# Altium schematic sheet Sch.SchDoc
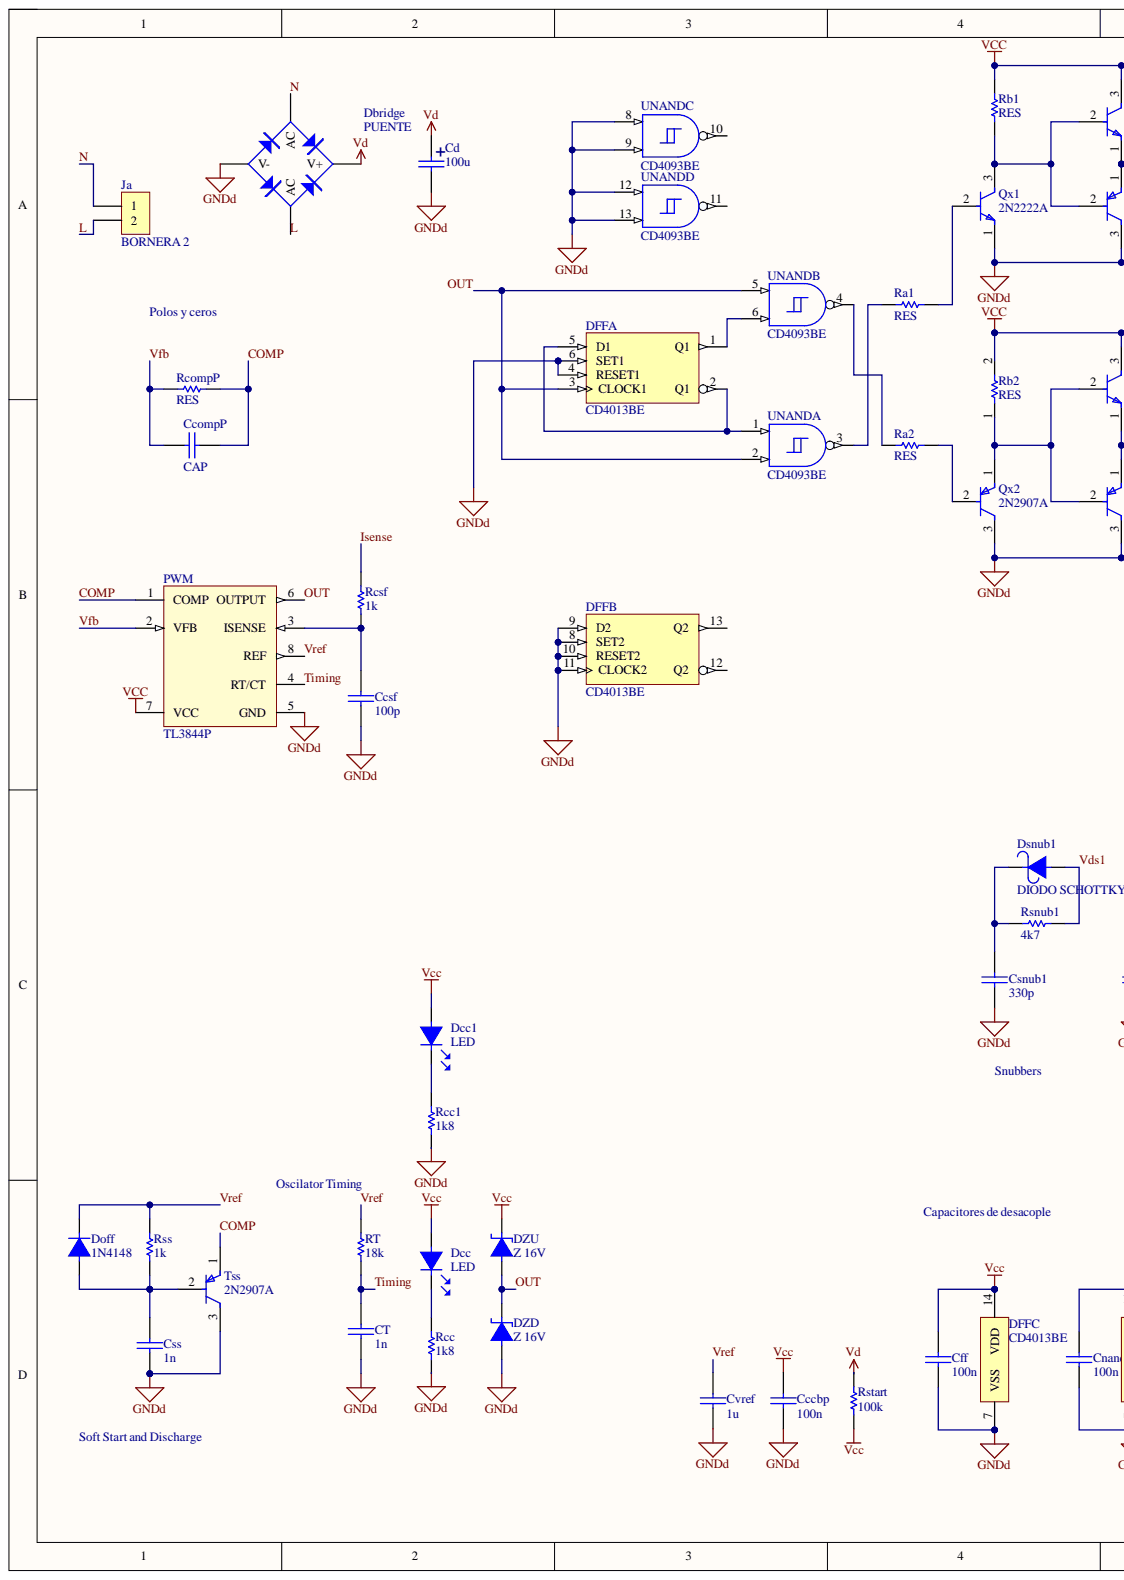
[diagram p0: Sch.SchDoc, left]
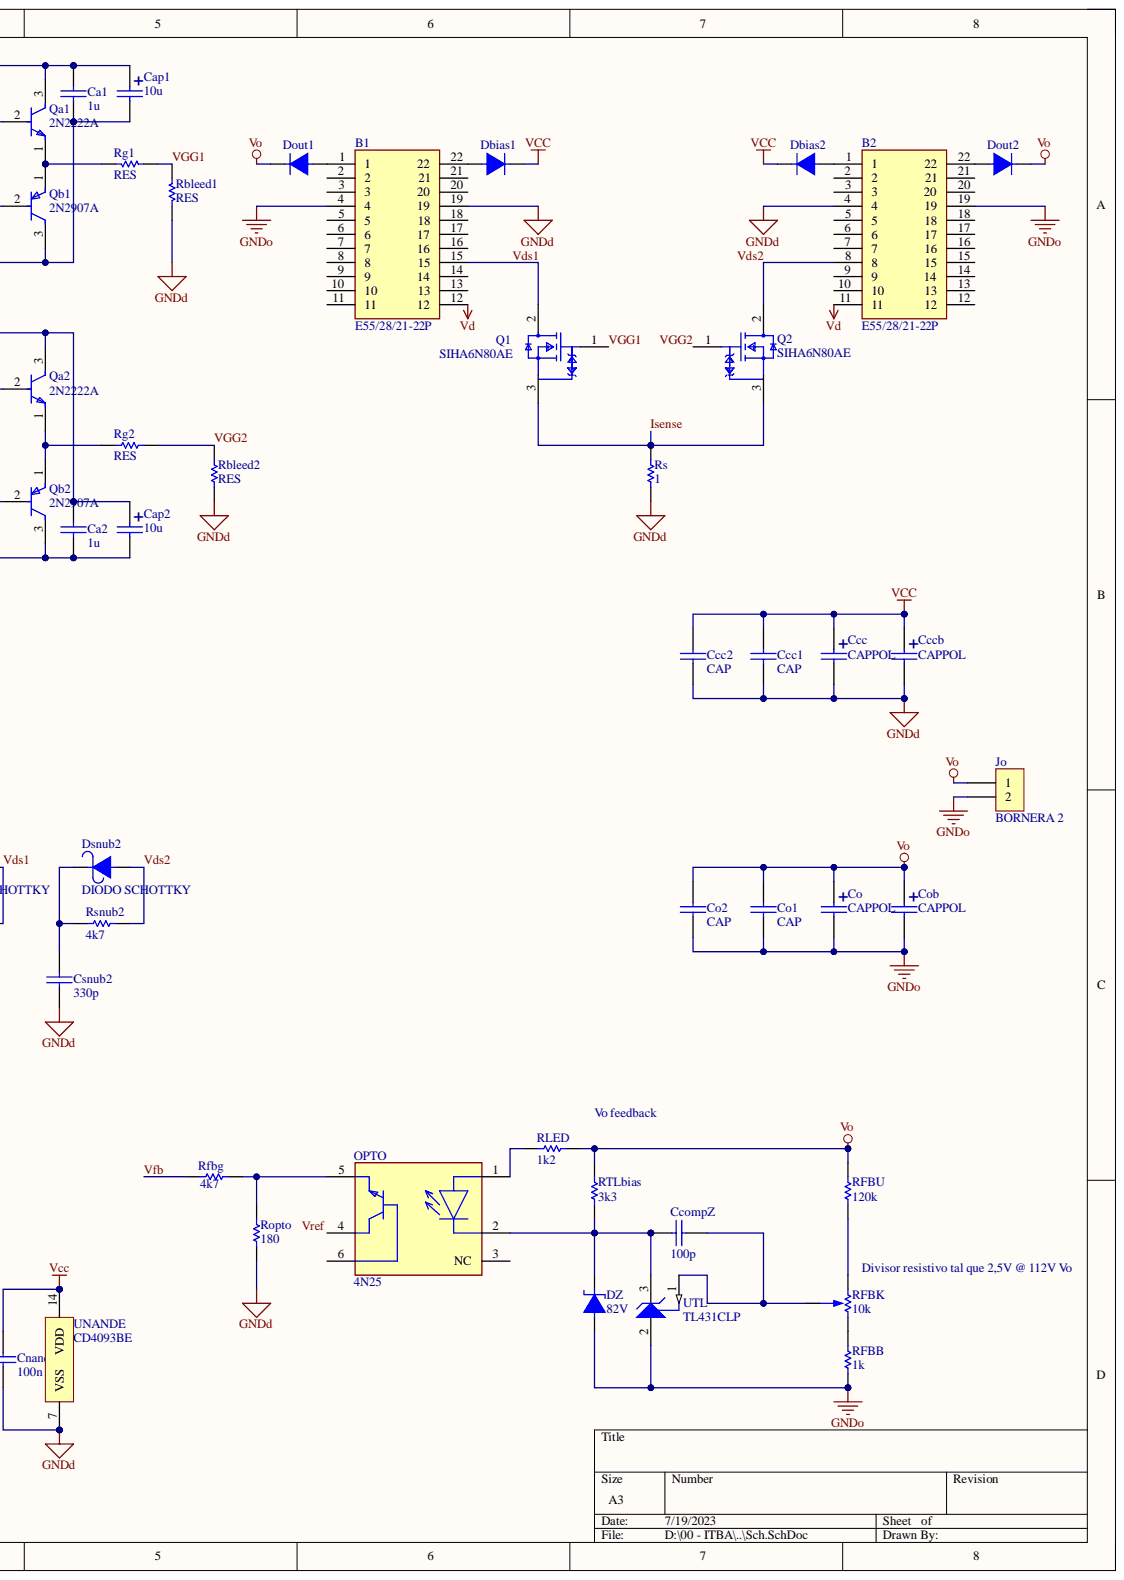
[diagram p1: Sch.SchDoc, right]

## Parts
B1  E55/28/21-22P  [DIPS4000W100P500L5500H4050Q22N]
B2  E55/28/21-22P  [DIPS4000W100P500L5500H4050Q22N]
Ca1  1u  [CAP100]
Ca2  1u  [CAP100]
Cap1  10u  [CAP100RP2]
Cap2  10u  [CAP100RP2]
Ccc  CAPPOL  [CAP200RP]
Ccc1  CAP  [CAP200]
Ccc2  CAP  [CAP200]
Cccb  CAPPOL  [CAP200RP]
Cccbp  100n  [CAP200]
CcompP  CAP  [CAP200]
CcompZ  100p  [CAP200]
Ccsf  100p  [CAP200]
Cd  100u  [CAP200RP]
Cff  100n  [CAP200]
Cnand  100n  [CAP200]
Co  CAPPOL  [CAP200RP]
Co1  CAP  [CAP200]
Co2  CAP  [CAP200]
Cob  CAPPOL  [CAP200RP]
Csnub1  330p  [CAP200]
Csnub2  330p  [CAP200]
Css  1n  [CAP200]
CT  1n  [CAP100]
Cvref  1u  [CAP100]
Dbias1  (no value)  [DO41]
Dbias2  (no value)  [DO41]
Dbridge  PUENTE  [PUENTE - W10M]
Dcc  LED  [LED3]
Dcc1  LED  [LED3]
DFF  CD4013BE  [N0014A]  (parts A,B,C)
Doff  1N4148  [DO41]
Dout1  (no value)  [DO41]
Dout2  (no value)  [DO41]
Dsnub1  DIODO SCHOTTKY  [DO41]
Dsnub2  DIODO SCHOTTKY  [DO41]
DZ  82V  [DO41]
DZD  Z 16V  [DO41]
DZU  Z 16V  [DO41]
Ja  BORNERA 2  [BORNERA2]
Jo  BORNERA 2  [BORNERA2]
OPTO  4N25  [FP-4N25-MFG]
PWM  TL3844P  [P0008A]
Q1  SIHA6N80AE  [FP-TO-220FP-7012510-MFG]
Q2  SIHA6N80AE  [FP-TO-220FP-7012510-MFG]
Qa1  2N2222A  [FP-2N2222A-MFG]
Qa2  2N2222A  [FP-2N2222A-MFG]
Qb1  2N2907A  [FP-TO-18-MFG]
Qb2  2N2907A  [FP-TO-18-MFG]
Qx1  2N2222A  [FP-2N2222A-MFG]
Qx2  2N2907A  [FP-TO-18-MFG]
Ra1  RES  [RES300]
Ra2  RES  [RES300]
Rb1  RES  [RES100]
Rb2  RES  [RES100]
Rbleed1  RES  [RES100]
Rbleed2  RES  [RES100]
Rcc  1k8  [RES300]
Rcc1  1k8  [RES300]
RcompP  RES  [RES300]
Rcsf  1k  [RES400]
RFBB  1k  [RES400]
Rfbg  4k7  [RES300]
RFBK  10k  [PRESET V]
RFBU  120k  [RES400]
Rg1  RES  [RES300]
Rg2  RES  [RES300]
RLED  1k2  [RES400]
Ropto  180  [RES300]
Rs  1  [RES400]
Rsnub1  4k7  [RES500]
Rsnub2  4k7  [RES500]
Rss  1k  [RES400]
Rstart  100k  [RES400]
RT  18k  [RES300]
RTLbias  3k3  [RES400]
Tss  2N2907A  [FP-TO-18-MFG]
UNAND  CD4093BE  [N0014A]  (parts A,B,C,D,E)
UTL  TL431CLP  [LP0003A]

## Nets
GNDd: B1.19 B2.4 Ca1.2 Ca2.2 Cap1.2 Cap2.2 Ccc.2 Ccc1.2 Ccc2.2 Cccb.2 Cccbp.2 Ccsf.2 Cd.2 Cff.2 Cnand.2 Csnub1.2 Csnub2.2 Css.2 CT.2 Cvref.2 Dbridge.3(V-) DFF.4(RESET1) DFF.6(SET1) DFF.7(VSS) DFF.8(SET2) DFF.9(D2) DFF.10(RESET2) DFF.11(CLOCK2) DZD.1 PWM.5(GND) Qb1.3 Qb2.3 Qx1.1 Qx2.3 Rbleed1.2 Rbleed2.2 Rcc.1 Rcc1.1 Ropto.1 Rs.1 Tss.3 UNAND.7(VSS) UNAND.8 UNAND.9 UNAND.12 UNAND.13
GNDo: B1.4 B2.19 Co.2 Co1.2 Co2.2 Cob.2 DZ.1 Jo.2 RFBB.2 UTL.2
Vcc: Ca1.1 Ca2.1 Cap1.1 Cap2.1 Ccc.1 Ccc1.1 Ccc2.1 Cccb.1 Cccbp.1 Cff.1 Cnand.1 Dbias1.2 Dbias2.2 Dcc.1 Dcc1.1 DFF.14(VDD) DZU.2 PWM.7(VCC) Qa1.3 Qa2.3 Rb1.2 Rb2.2 Rstart.1 UNAND.14(VDD)
Vd: B1.12 B2.11 Cd.1 Dbridge.1(V+) Rstart.2
Vo: Co.1 Co1.1 Co2.1 Cob.1 Dout1.2 Dout2.2 Jo.1 RFBU.1 RLED.2 RTLbias.2
COMP: CcompP.2 PWM.1(COMP) RcompP.2 Tss.1
Isense: Q1.3 Q2.3 Rcsf.2 Rs.2
L: Dbridge.4(AC) Ja.2
N: Dbridge.2(AC) Ja.1
OUT: DFF.3(CLOCK1) DZD.2 DZU.1 PWM.6(OUTPUT) UNAND.2 UNAND.5
Timing: CT.1 PWM.4(RT/CT) RT.1
Vds1: B1.15 Dsnub1.1 Q1.2 Rsnub1.1
Vds2: B2.8 Dsnub2.1 Q2.2 Rsnub2.2
Vfb: CcompP.1 PWM.2(VFB) RcompP.1 Rfbg.2
VGG1: Q1.1 Rbleed1.1 Rg1.1
VGG2: Q2.1 Rbleed2.1 Rg2.2
Vref: Cvref.1 Doff.2 OPTO.4 PWM.8(REF) Rss.1 RT.2
NetB1_1: B1.1 Dout1.1
NetB1_22: B1.22 Dbias1.1
NetB2_1: B2.1 Dbias2.1
NetB2_22: B2.22 Dout2.1
NetCcompZ_1: CcompZ.1 DZ.2 OPTO.2 RTLbias.1 UTL.3
NetCcompZ_2: CcompZ.2 RFBK.2 UTL.1
NetCcsf_1: Ccsf.1 PWM.3(ISENSE) Rcsf.1
NetCsnub1_1: Csnub1.1 Dsnub1.2 Rsnub1.2
NetCsnub2_1: Csnub2.1 Dsnub2.2 Rsnub2.1
NetCss_1: Css.1 Doff.1 Rss.2 Tss.2
NetDcc1_2: Dcc1.2 Rcc1.2
NetDcc_2: Dcc.2 Rcc.2
NetDFF_1: DFF.1(Q1) UNAND.6
NetDFF_2: DFF.2(Q1) DFF.5(D1) UNAND.1
NetOPTO_1: OPTO.1 RLED.1
NetOPTO_5: OPTO.5 Rfbg.1 Ropto.2
NetQa1_1: Qa1.1 Qb1.1 Rg1.2
NetQa1_2: Qa1.2 Qb1.2 Qx1.3 Rb1.1
NetQa2_1: Qa2.1 Qb2.1 Rg2.1
NetQa2_2: Qa2.2 Qb2.2 Qx2.1 Rb2.1
NetQx1_2: Qx1.2 Ra1.2
NetQx2_2: Qx2.2 Ra2.2
NetRa1_1: Ra1.1 UNAND.3
NetRa2_1: Ra2.1 UNAND.4
NetRFBB_1: RFBB.1 RFBK.1
NetRFBK_3: RFBK.3 RFBU.2

Not connected: B1 2 3 5 6 7 8 9 10 11 13 14 16 17 18 20 21; B2 2 3 5 6 7 9 10 12 13 14 15 16 17 18 20 21; DFF 12(Q2) 13(Q2); OPTO 3(NC) 6; UNAND 10 11

## Library data of the parts
B1 B2 = CPH-E55_28_21-1S-22P-Z: COIL FORMER HORIZONTAL; Manufacturer_Name: FERROXCUBE; Manufacturer_Part_Number: CPH-E55/28/21-1S-22P-Z; Geometry.Height: 40.5mm; Component Kind: Standard; Datasheet Link: https://www.ferroxcube.com/upload/media/product/file/Pr_ds/E55_28_21.pdf; Library Name: CPH-E55_28_21-1S-22P-Z.SchLib
Ca1 Ca2 Cccbp CcompZ Ccsf Cff Cnand Csnub1 Csnub2 Css CT Cvref = CAP: Component Kind: Standard; Library Name: LIBEBAL.IntLib
Cap1 Cap2 Cd = CAPPOL: Component Kind: Standard; Library Name: LIBEBAL.IntLib
Dbias1 Dbias2 Doff Dout1 Dout2 = DIODO: Component Kind: Standard; Library Name: LIBEBAL.IntLib
DFF = CMP-1619-00744-2: CMOS Dual D-Type Flip Flop, N0014A, TUBE; Manufacturer: Texas Instruments; 3-State Output: No; Bits(#): 2; F @ Nom Voltage(Max)(Mhz): 8; ICC @ Nom Voltage(Max)(mA): 0.06; Output Drive (IOL/IOH)(Max)(mA): 1.5/-1.5; Output Logic: Inverted,Non-inverted; Rating: Military; Technology Family: CD4000; tpd @ Nom Voltage(Max)(ns): 130; VCC(Max)(V): 18; VCC(Min)(V): 3; Voltage(Nom)(V): 10; Code_JEDEC: MS-001; Component Kind: Standard; DatasheetVersion: SCHS023D; Generic Part Number: CD4013B; Input Type: CMOS; Library Name: Altium Content Vault; Operating Temperature Range(C): -55 to 125; Output Type: CMOS; PackageReference: N0014A; Schmitt Trigger: No
DZ DZD DZU = DIODO ZENER: Component Kind: Standard; Library Name: LIBEBAL.IntLib
OPTO = CMP-22018-000009-1: OPTOISO 2.5KV TRANS W/BASE 6DIP; Collector Emitter Breakdown Voltage: 30V; Collector Emitter Saturation Voltage: 500mV; Current Transfer Ratio: 50%; Fall Time: 2us; Forward Current: 60mA; Forward Voltage: 1.5V; Input Current: 50mA; Input Type: DC; Isolation Voltage: 5kV; Max Collector Current: 100mA; Max Input Current: 80mA; Max Power Dissipation: 250mW; Number of Channels: 1; Output Voltage: 70V; Power Dissipation: 150mW; Reverse Breakdown Voltage: 5V; Case/Package: PDIP; Collector Emitter Voltage (VCEO): 30V; Collector-emitter Voltage-Max: 500mV; Component Kind: Standard; Isolation Voltage-Max: 5kV; Lead Free: Lead Free; Library Name: Altium Content Vault; Max Operating Temperature: 100°C; Max Output Voltage: 30V; Min Operating Temperature: -55°C; Mount: Through Hole; Number of Circuits: 1; Number of Elements: 1; Number of Pins: 6; Output Current per Channel: 100mA; Package Quantity: 2000; Packaging: Rail/Tube; Radiation Hardening: No; Rise Time: 2us; Series: -
PWM = CMP-0319-00110-2: PWM Controller with Current Control, 50 % Duty Cycle, 0 to +70 degC, 8-pin PDIP (P), Pb-Free (RoHS); Manufacturer: Texas Instruments; Duty Cycle(Max)(%): 50; Frequency(Max)(kHz): 500; Rating: Catalog; Regulated Outputs(#): 1; Topology: Boost, Flyback, Forward; UVLO Thresholds On/Off(V): 16/10; Code_JEDEC: MS-001-BA; Component Kind: Standard; ComponentLink1Description: Datasheet; ComponentLink1URL: http://www.ti.com/general/docs/lit/getliterature.tsp?genericPartNumber=TL3844&fileType=pdf; Control Method: Current; DatasheetVersion: SLVS038G; Eco-Plan: Pb-Free (RoHS); Library Name: Altium Content Vault; Mounting Technology: Through Hole; PackageDescription: 8-Pin Plastic Dual-In-Line Package, Row Spacing 7.62 mm, Pitch 2.54 mm; PackageReference: P0008A; PackageVersion: revE, Apr-2010; PartNumber: TL3844P; SubFamily: PWM Controller
Q1 Q2 = CMP-12198-000018-1: MOSFET N-CH 800V 12A TO-220FP; Manufacturer: STMicroelectronics; Manufacturer Part Number: STF13N80K5; Depletion Mode: False; Drain To Source Resistance: 0.37Ω; Gate Charge At Vgs: 29nC @ 10V; Gate To Source Voltage: 30V; Input Capacitace At Vds: 870pF @ 100V; Max Forward Diode Voltage: 1.5V; Max Junction Temp: +150°C; Number Of Channels: 1; Power Dissipation: 35W; Pulse Drain Current: 48A; Reverse Recovery Charge: 5700nC; Reverse Recovery Time: 406ns; Rthja Max: 62.5°C/W; Standoff Height: 2.9mm; Threshold Vgs Max: 5V; Threshold Vgs Min: 3V; Turn Off Delay Time: 42ns; Turn On Delay Time: 16ns; Automotive: No; Category: Trans; Component Kind: Standard; ComponentLink2Description: Datasheet; ComponentLink2URL: https://www.st.com/resource/en/datasheet/stf13n80k5.pdf; Continuous Drain Current: 12A; Device Class L1: Discrete Semiconductors; Device Class L2: Transistors; Device Class L3: MOSFETs; Digikey Description: MOSFET N-CH 800V 12A TO-220FP; Drain To Source Breakdown Voltage: 800V; Drain To Source Voltage: 800V; Height: 20mm; Lead Free: Yes; Library Name: Altium Content Vault; Mouser Description: MOSFET N-Ch 800 V 0.37 Ohm 12 A Zener-protect; Number Of N Channels: 1; Octopart: https://octopart.com/stf13n80k5-stmicroelectronics-29487922; Package: TO-220FP-7012510; RoHS: TRUE; Temperature: -55°C to +150°C; Temperature Range High: +150°C; Temperature Range Low: -55°C
Qa1 Qa2 Qx1 = CMP-50385-000001-1: T-NPN SI- AUDIO VHF AMP; Collector Emitter Breakdown Voltage: 40V; Max Power Dissipation: 1.2W; Polarity: NPN; Transition Frequency: 300MHz; Case/Package: TO-18; Collector Emitter Voltage (VCEO): 40V; Component Kind: Standard; Lead Free: Contains Lead; Library Name: Altium Content Vault; Max Operating Temperature: 200°C; Number of Pins: 3; REACH SVHC: No SVHC
Qb1 Qb2 Qx2 Tss = CMP-06366-000010-1: TRANSISTOR PNP 60V 0.8AMP TO-18; Component Kind: Standard; Library Name: Altium Content Vault
Rcc Rcc1 Rcsf RFBB Rfbg RFBU RLED Ropto Rs Rsnub1 Rsnub2 Rss Rstart RT RTLbias = RES: Component Kind: Standard; Library Name: LIBEBAL.IntLib
RFBK = PRESET: Component Kind: Standard; Library Name: LIBEBAL.IntLib
UNAND = CMP-1627-00493-3: CMOS Quad 2-Input NAND Schmitt Triggers, N0014A, TUBE; Manufacturer: Texas Instruments; Bits(#): 4; F @ Nom Voltage(Max)(Mhz): 8; ICC @ Nom Voltage(Max)(mA): 0.06; Output Drive (IOL/IOH)(Max)(mA): 1.5/-1.5; Rating: Catalog; Schmitt Trigger: Yes; Technology Family: CD4000; VCC(Max)(V): 18; VCC(Min)(V): 3; Voltage(Nom)(V): 10; Code_JEDEC: MS-001; Component Kind: Standard; DatasheetVersion: SCHS115D; Generic Part Number: CD4093B; Input Type: CMOS; Library Name: Altium Content Vault; Operating Temperature Range(C): -55 to 125; Output Type: CMOS; PackageReference: N0014A
UTL = CMP-0366-00032-2: Adjustable Precision Shunt Regulator, 34 ppm / degC, 100 mA, 0 to 70 degC, 3-pin TO-92 (LP), Pb-Free (RoHS); Manufacturer: Texas Instruments; Initial Accuracy @ 25/deg C(%): 2; Iout/Iz(Max)(mA): 100; Min Iz for Regulation(uA): 600; Rating: Military; SubFamily: Shunt Voltage Reference; Temp Coeff(Max)(ppm/ degree C): 92; Temp Coeff(Typ)(ppm/ degree C): 34; VO Adj(Max)(V): 36; VO Adj(Min)(V): 2.495; Code_JEDEC: TO-226; Component Kind: Standard; ComponentLink1Description: Manufacturer URL; ComponentLink1URL: http://www.ti.com/; ComponentLink2Description: Datasheet; ComponentLink2URL: http://www.ti.com/general/docs/lit/getliterature.tsp?genericPartNumber=TL431&fileType=pdf; ComponentLink3Description: Package Specification; ComponentLink3URL: http://www.ti.com/litv/pdf/msot002a; DatasheetVersion: SLVS543M; Eco-Plan: Pb-Free (RoHS); Library Name: Altium Content Vault; Mounting Technology: Through Hole; PackageDescription: Plastic Cylindrical Package, Formed Lead Option (TO-92); PackageReference: LP0003A; PackageVersion: 4040001-2/C; PartNumber: TL431CLP
Ccc Cccb Co Cob = CAPPOL: Component Kind: Standard; Library Name: LIBEBAL.IntLib
Ccc1 Ccc2 CcompP Co1 Co2 = CAP: Component Kind: Standard; Library Name: LIBEBAL.IntLib
Dbridge = PUENTE: Component Kind: Standard; Library Name: LIBEBAL.IntLib
Dcc Dcc1 = LED: Component Kind: Standard; Library Name: LIBEBAL.IntLib
Dsnub1 Dsnub2 = DIODO SCHOTTKY: Component Kind: Standard; Library Name: LIBEBAL.IntLib
Ja Jo = BORNERA 2: Component Kind: Standard; Library Name: LIBEBAL.IntLib
Ra1 Ra2 Rb1 Rb2 Rbleed1 Rbleed2 RcompP Rg1 Rg2 = RES: Component Kind: Standard; Library Name: LIBEBAL.IntLib
